# Altium schematic sheet schematic.SchDoc
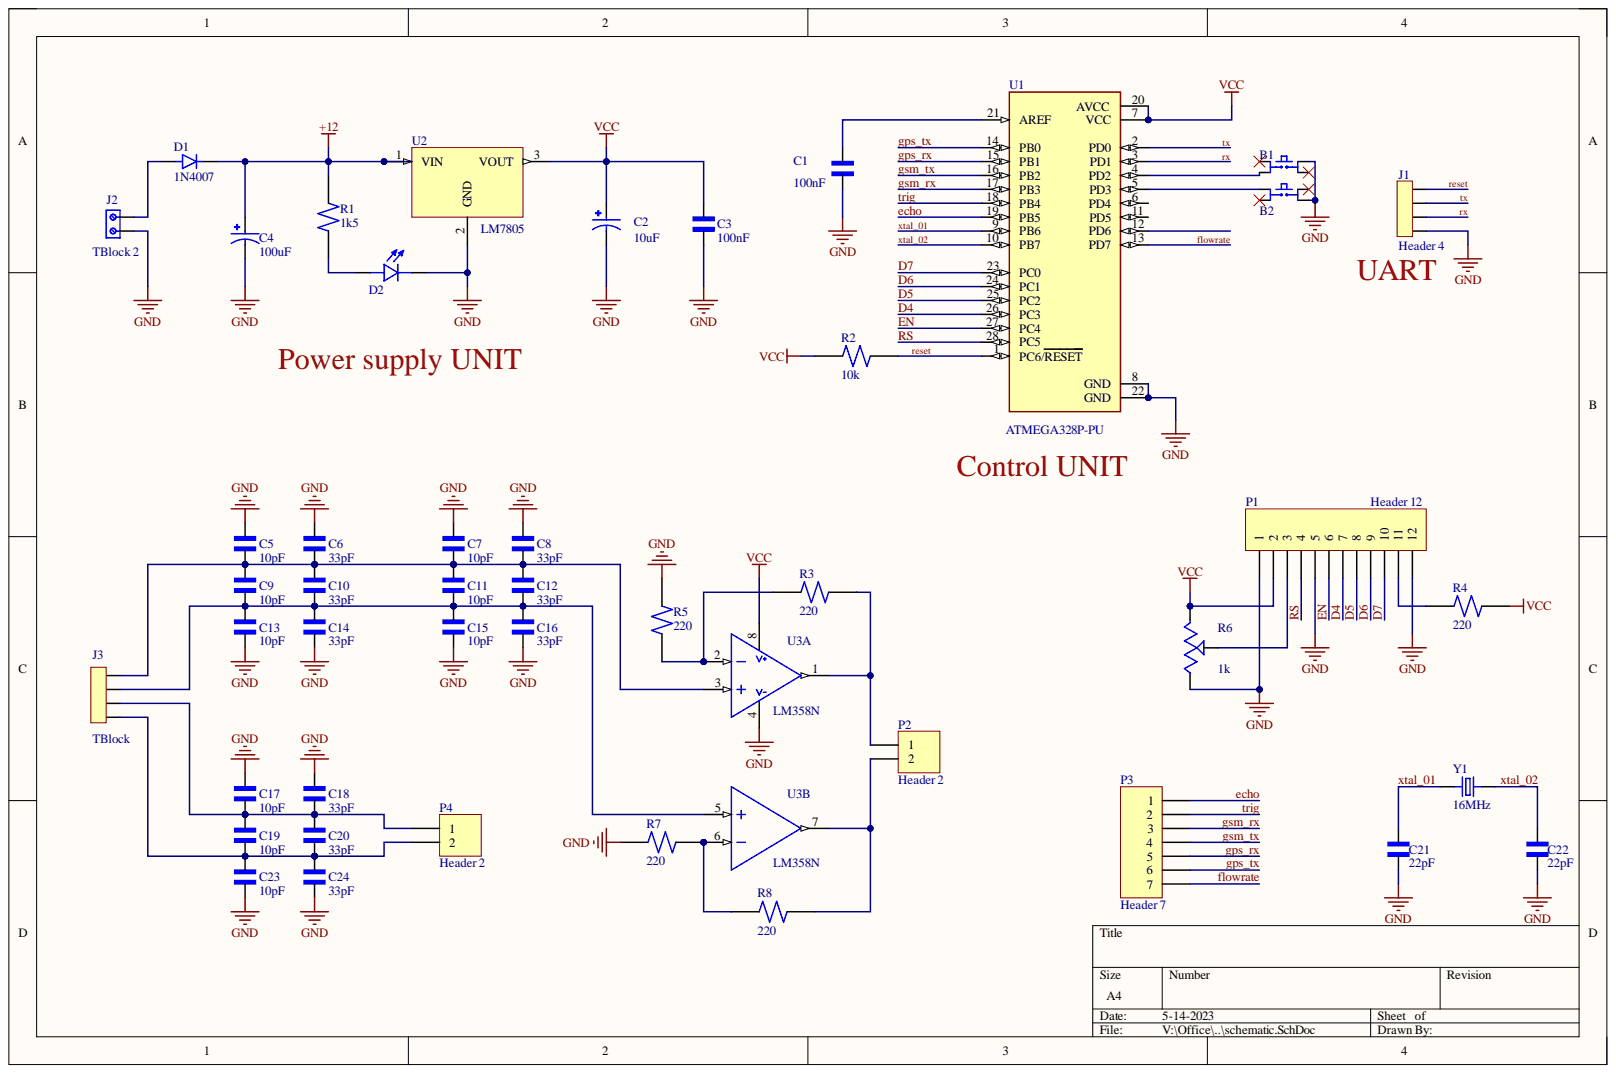
[diagram p0: schematic.SchDoc]

## Parts
B1  Push button  [SW_TS02-66-95-BK-260-LCR-D]  (value not drawn)
B2  Push button  [SW_TS02-66-95-BK-260-LCR-D]  (value not drawn)
C1  100nF  [CAPRB500W50L450T300H550]
C2  10uF  [CAPPRD500W50D500H1100]
C3  100nF  [CAPRB500W50L450T300H550]
C4  100uF  [CAPPRD500W50D500H1100]
C5  10pF  [CAPRB500W50L450T300H550]
C6  33pF  [CAPRB500W50L450T300H550]
C7  10pF  [CAPRB500W50L450T300H550]
C8  33pF  [CAPRB500W50L450T300H550]
C9  10pF  [CAPRB500W50L450T300H550]
C10  33pF  [CAPRB500W50L450T300H550]
C11  10pF  [CAPRB500W50L450T300H550]
C12  33pF  [CAPRB500W50L450T300H550]
C13  10pF  [CAPRB500W50L450T300H550]
C14  33pF  [CAPRB500W50L450T300H550]
C15  10pF  [CAPRB500W50L450T300H550]
C16  33pF  [CAPRB500W50L450T300H550]
C17  10pF  [CAPRB500W50L450T300H550]
C18  33pF  [CAPRB500W50L450T300H550]
C19  10pF  [CAPRB500W50L450T300H550]
C20  33pF  [CAPRB500W50L450T300H550]
C21  22pF  [CAPRB500W50L450T300H550]
C22  22pF  [CAPRB500W50L450T300H550]
C23  10pF  [CAPRB500W50L450T300H550]
C24  33pF  [CAPRB500W50L450T300H550]
D1  1N4007  [DIOAD1060W80L520D270]
D2  L08R5000Q1  [LEDRD254W57D500H1070]  (value not drawn)
J1  Header 4  [SULLINS_PPTC041LFBN-RC]
J2  TBlock 2  [691111710002]
J3  TBlock  [SULLINS_PPTC041LFBN-RC]
P1  Header 12  [HDR1X12]
P2  Header 2  [HDR1X2]
P3  Header 7  [HDR1X7]
P4  Header 2  [HDR1X2]
R1  1k5  [RESAD1100W55L680D260]
R2  10k  [RESAD1100W55L680D260]
R3  220  [RESAD1100W55L680D260]
R4  220  [RESAD1100W55L680D260]
R5  220  [RESAD1100W55L680D260]
R6  1k  [TRIM_3306F-1-105]
R7  220  [RESAD1100W55L680D260]
R8  220  [RESAD1100W55L680D260]
U1  ATMEGA328P-PU  [DIP794W46P254L2967H457Q28B]
U2  LM7805  [TO255P1020X450X1968-3]
U3  LM358N  [DIP794W45P254L959H508Q8]  (parts A,B)
Y1  16MHz  [XTAL_ECS-160-20-4X]

## Nets
+12: C4.P D1.C R1.2 U2.1
GND: B1.3 B2.4 C1.1 C2.N C3.1 C4.N C5.2 C6.2 C7.2 C8.2 C13.1 C14.1 C15.1 C16.1 C17.2 C18.2 C21.1 C22.1 C23.1 C24.1 D2.C J1.4 J2.1 P1.1 P1.5 P1.12 R5.1 R6.1 R7.1 U1.8(GND) U1.22(GND) U2.2 U3.4
VCC: C2.P C3.2 P1.2 R2.2 R4.1 R6.3 U1.7(VCC) U1.20(AVCC) U2.3 U3.8
D4: P1.7 U1.26(PC3)
D5: P1.8 U1.25(PC2)
D6: P1.9 U1.24(PC1)
D7: P1.10 U1.23(PC0)
echo: P3.1 U1.19(PB5)
EN: P1.6 U1.27(PC4)
flowrate: P3.7 U1.13(PD7)
gps_rx: P3.5 U1.15(PB1)
gps_tx: P3.6 U1.14(PB0)
gsm_rx: P3.3 U1.17(PB3)
gsm_tx: P3.4 U1.16(PB2)
reset: J1.1 R2.1 U1.1(PC6/RESET)
RS: P1.4 U1.28(PC5)
rx: J1.3 U1.3(PD1)
trig: P3.2 U1.18(PB4)
tx: J1.2 U1.2(PD0)
xtal_01: C21.2 U1.9(PB6) Y1.1
xtal_02: C22.2 U1.10(PB7) Y1.2
NetB1_2: B1.2 U1.4(PD2)
NetB2_1: B2.1 U1.5(PD3)
NetC1_2: C1.2 U1.21(AREF)
NetC5_1: C5.1 C6.1 C7.1 C8.1 C9.2 C10.2 C11.2 C12.2 J3.1 U3.3
NetC9_1: C9.1 C10.1 C11.1 C12.1 C13.2 C14.2 C15.2 C16.2 J3.2 U3.5
NetC17_1: C17.1 C18.1 C19.2 C20.2 J3.3 P4.1
NetC19_1: C19.1 C20.1 C23.2 C24.2 J3.4 P4.2
NetD1_A: D1.A J2.2
NetD2_A: D2.A R1.1
NetP1_3: P1.3 R6.2
NetP1_11: P1.11 R4.2
NetP2_1: P2.1 R3.2 U3.1
NetP2_2: P2.2 R8.2 U3.7
NetR3_1: R3.1 R5.2 U3.2
NetR7_2: R7.2 R8.1 U3.6

Not connected: B1 1 4; B2 2 3; U1 6(PD4) 11(PD5) 12(PD6)

## Library data of the parts
C1 C3 C5-C24 = Ceramic capacitor: 2200 pF Â±10% 250V Ceramic Capacitor X7R Radial; MF: TDK; MP: FG24X7R2E222KNT06; Check_prices: https://www.snapeda.com/parts/FG24X7R2E222KNT06/TDK/view-part/?ref=eda; Component Kind: Standard; Digi-Key_Part_Number: 445-173386-1-ND; Package: Radial TDK; Purchase-URL: https://pricing.snapeda.com/search/part/FG24X7R2E222KNT06/?ref=eda; SnapEDA_Link: https://www.snapeda.com/parts/FG24X7R2E222KNT06/TDK/view-part/?ref=snap; Library Name: Installed library.IntLib
C2 C4 = Electrolytic capacitor: FS-A; MF: {'manufacturer': u'Panasonic'}; MP: EEUFS0J221B; Availability: Unavailable; Component Kind: Standard; Package: None; Price: None; Library Name: Installed library.IntLib
D1 = 1N4007: Diode Switching 1KV 1A 2-Pin DO-41; Availability: Unavailable; Component Kind: Standard; Library Name: Components.IntLib; MP: 1N4007; Package: None; Price: None
D2 = LED: LED, Orange, Through Hole, T-1 3/4 (5mm), 30 mA, 2.1 V, 635 nm; MF: {'manufacturer': u'LED Technology'}; Availability: Unavailable; Component Kind: Standard; MP: L08R5000Q1; Package: None; Price: None; Library Name: Installed library.IntLib
J1 J3 = Female header - 4Pins: 4 Position Header Connector Through Hole; MF: Sullins Connector; MP: PPTC041LFBN-RC; Availability: Warning; Check_prices: https://www.snapeda.com/parts/PPTC041LFBN-RC/Sullins%20Connector%20Solutions/view-part/285917/?ref=eda; Component Kind: Standard; Package: None; Price: None; Purchase-URL: https://pricing.snapeda.com/search/part/PPTC041LFBN-RC/?ref=eda; SnapEDA_Link: https://www.snapeda.com/parts/PPTC041LFBN-RC/Sullins%20Connector%20Solutions/view-part/285917/?ref=snap; Library Name: Installed library.IntLib
J2 = Terminal Block - 2Pins: 2 Position Wire to Board Terminal Block Horizontal with Board 0.197 _5.00mm_ Through Hole; MF: Wurth Electronics; Availability: Unavailable; Component Kind: Standard; MP: 691111710002; Package: None; Price: None; SnapEDA_Link: https://www.snapeda.com/parts/691111710002/Wurth%20Electronics%20Inc./view-part/1195092/?ref=snap; Library Name: Installed library.IntLib
P1 = Header 12: Header, 12-Pin; Component Kind: Standard; LatestRevisionDate: 17-Jul-2002; LatestRevisionNote: Re-released for DXP Platform.; Library Name: Miscellaneous Connectors.IntLib; Signal Integrity: Connector; Publisher: Altium Limited
P2 P4 = Header 2: Header, 2-Pin; Component Kind: Standard; LatestRevisionDate: 17-Jul-2002; LatestRevisionNote: Re-released for DXP Platform.; Library Name: Miscellaneous Connectors.IntLib; Signal Integrity: Connector; Publisher: Altium Limited
P3 = Header 7: Header, 7-Pin; Component Kind: Standard; LatestRevisionDate: 17-Jul-2002; LatestRevisionNote: Re-released for DXP Platform.; Library Name: Miscellaneous Connectors.IntLib; Signal Integrity: Connector; Publisher: Altium Limited
R1-R5 R7 R8 = Resistor (0.25W): (MFR-25FBF52-10K) 10,2 kOhm bei 1% 0,25W, 1/4W Durchbohrlochwiderstand Axial Metal Film; MF: Yageo; Component Kind: Standard; Digi-Key_Part_Number: 10.2KXBK-ND; MP: MFR-25FBF52-10K; Package: Axial Yageo; Purchase-URL: https://pricing.snapeda.com/search/part/MFR-25FBF52-10K/?ref=eda; SnapEDA_Link: https://www.snapeda.com/parts/MFR-25FBF52-10K/Yageo/view-part/424333/?ref=snap; Library Name: Installed library.IntLib
R6 = Potentiometer1: (3306F-1-105) 1 MOhms 0.2W, 1/5W PC Pins Through Hole Trimmer Potentiometer Cermet 1 Turn Top Adjustment; MF: Bourns; Availability: Good; Check_prices: https://www.snapeda.com/parts/3306F-1-105/Bourns%20-%20J.W.%20Miller/view-part/862876/?ref=eda; Component Kind: Standard; MP: 3306F-1-105; Package: 3306F Bourns; Price: None; Purchase-URL: https://pricing.snapeda.com/search/part/3306F-1-105/?ref=eda; SnapEDA_Link: https://www.snapeda.com/parts/3306F-1-105/Bourns%20-%20J.W.%20Miller/view-part/862876/?ref=snap; Library Name: Installed library.IntLib
U1 = ATMEGA328P-PU: ATmega Series 20 MHz 32 KB Flash 2 KB SRAM 8-Bit Microcontroller - DIP-28; Availability: Unavailable; Component Kind: Standard; MP: ATMEGA328P-PU; Package: None; Price: None; Library Name: Installed library.IntLib
U2 = LM78__: MP: LM7805CT/NOPB; Availability: Unavailable; Component Kind: Standard; Library Name: Voltage regulator.IntLib; Package: None; Price: None
U3 = LM358N/NOPB: <a href="https://snapeda.com/parts/LM358N/NOPB/Texas%20Instruments/view-part/?ref=eda">Check prices</a>; Availability: Unavailable; Component Kind: Standard; Library Name: OpAmp.IntLib; MP: LM358N/NOPB; Package: None; Price: None
Y1 = Crystal oscilator: CRYSTAL T/H; MF: {'manufacturer': u'ECS Inc.'}; MP: ECS-160-S-4; Availability: Unavailable; Component Kind: Standard; Package: None; Price: None; Library Name: Installed library.IntLib
B1 B2 = Push button: Component Kind: Standard; Library Name: Installed library.IntLib
